# Altium schematic sheet PAGE4 - MOTOR_DRIVERS.SchDoc
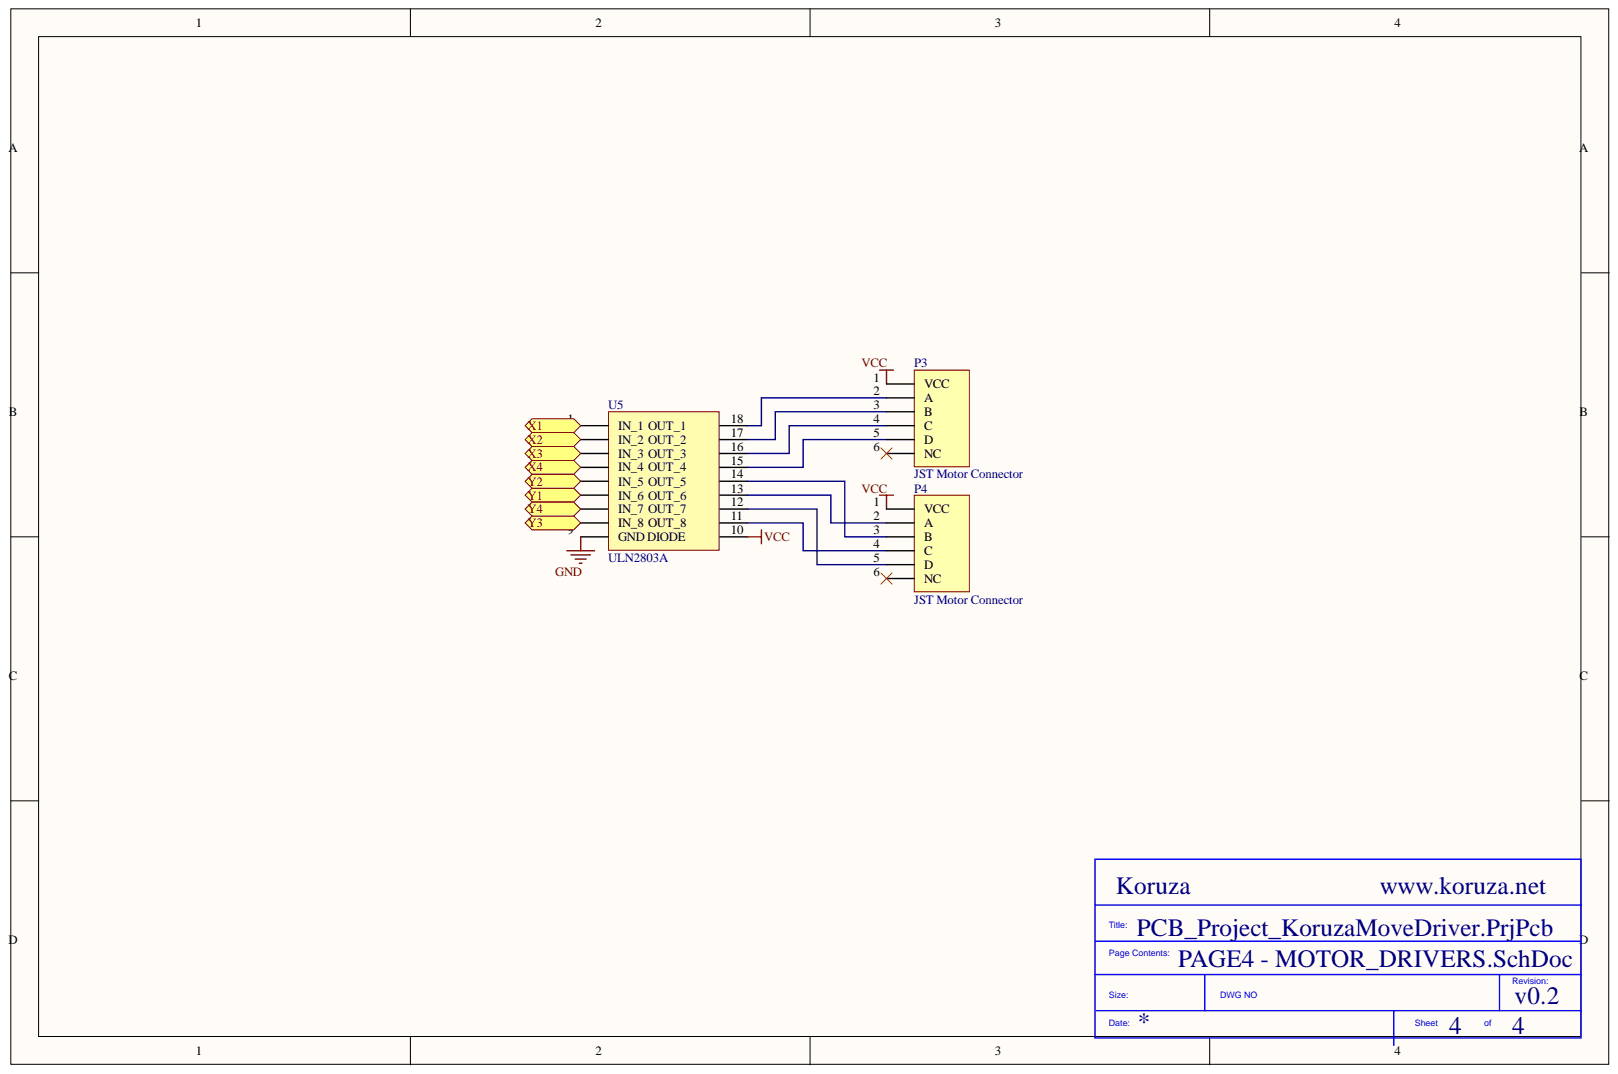
[diagram p0: PAGE4 - MOTOR_DRIVERS.SchDoc]

## Parts
P3  JST Motor Connector  [JST S6B-XH-SM4-TB - duplicate]
P4  JST Motor Connector  [JST S6B-XH-SM4-TB - duplicate]
U5  ULN2803A  [ULN2803A_SOIC18]

## Ports
X1, X2, X3, X4, Y1, Y2, Y3, Y4

## Nets
GND: U5.9
VCC: P3.1(VCC) P4.1(VCC) U5.10
X1: U5.1 ; port X1
X2: U5.2 ; port X2
X3: U5.3 ; port X3
X4: U5.4 ; port X4
Y1: U5.6 ; port Y1
Y2: U5.5 ; port Y2
Y3: U5.8 ; port Y3
Y4: U5.7 ; port Y4
NetP3_2: P3.2(A) U5.18
NetP3_3: P3.3(B) U5.17
NetP3_4: P3.4(C) U5.16
NetP3_5: P3.5(D) U5.15
NetP4_2: P4.2(A) U5.13
NetP4_3: P4.3(B) U5.14
NetP4_4: P4.4(C) U5.11
NetP4_5: P4.5(D) U5.12

Not connected: P3 6(NC); P4 6(NC)

## Library data of the parts
P3 P4 = motor_connector_2: JST unipolar motor connector; Component Kind: Standard; Library Name: Schlib1.SchLib
U5 = ULN2803A: Darlington array, 8 channels; Component Kind: Standard; Library Name: Schlib1.SchLib
